# Altium schematic sheet Mix_8ch.SchDoc(Mix_8ch)
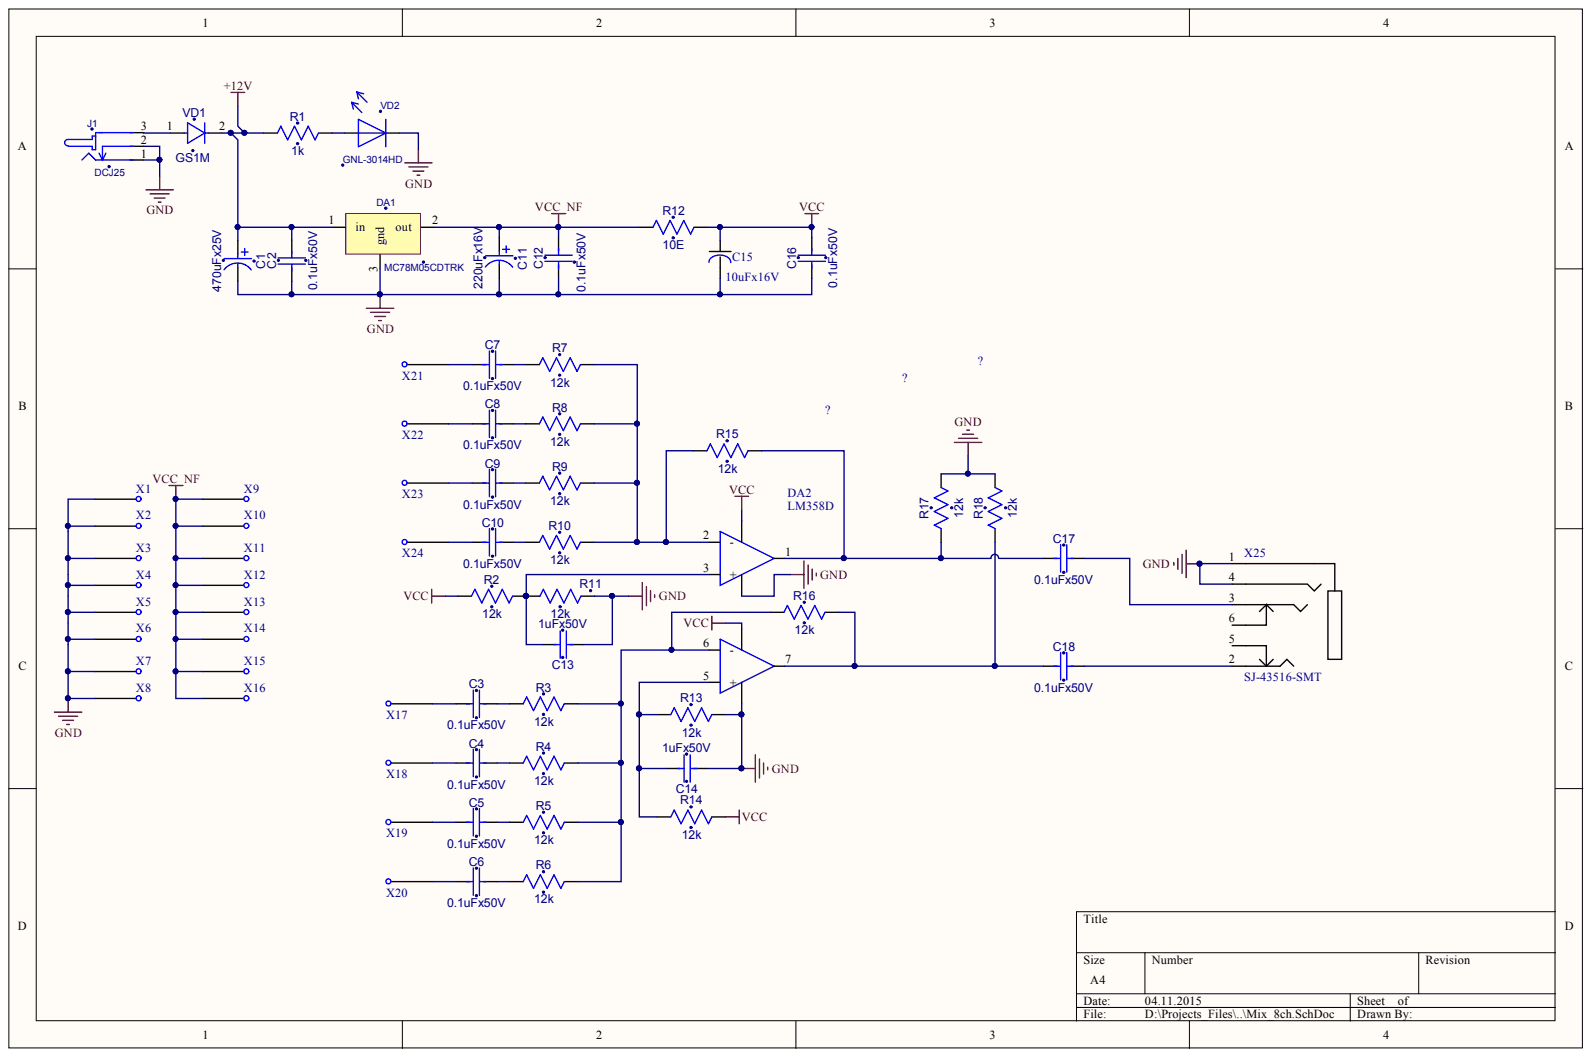
[diagram p0: Mix_8ch.SchDoc]

## Parts
?  REPER1.2  [REPER1.2]  (value not drawn)
?  REPER1.2  [REPER1.2]  (value not drawn)
?  REPER1.2  [REPER1.2]  (value not drawn)
C1  470uFx25V  [CC16X10_CAP0.5(1)(Primary)]
C2  0.1uFx50V  [CC0603-3M]
C3  0.1uFx50V  [CC0603-3M]
C4  0.1uFx50V  [CC0603-3M]
C5  0.1uFx50V  [CC0603-3M]
C6  0.1uFx50V  [CC0603-3M]
C7  0.1uFx50V  [CC0603-3M]
C8  0.1uFx50V  [CC0603-3M]
C9  0.1uFx50V  [CC0603-3M]
C10  0.1uFx50V  [CC0603-3M]
C11  220uFx16V  [CC8X3.5]
C12  0.1uFx50V  [CC0603-3M]
C13  1uFx50V  [CC0603-3M]
C14  1uFx50V  [CC0603-3M]
C15  10uFx16V  [Cap_5x8]
C16  0.1uFx50V  [CC0603-3M]
C17  0.1uFx50V  [CC0603-3M]
C18  0.1uFx50V  [CC0603-3M]
DA1  MC78M05CDTRK  [D-PAK(TO-252AA)]
DA2  LM358D  [SO-8]
J1  DCJ25  [DCJ25]
R1  1k  [RC0603-3M]
R2  12k  [RC0603-3M]
R3  12k  [RC0603-3M]
R4  12k  [RC0603-3M]
R5  12k  [RC0603-3M]
R6  12k  [RC0603-3M]
R7  12k  [RC0603-3M]
R8  12k  [RC0603-3M]
R9  12k  [RC0603-3M]
R10  12k  [RC0603-3M]
R11  12k  [RC0603-3M]
R12  10E  [RC0805_IPC_NOM]
R13  12k  [RC0603-3M]
R14  12k  [RC0603-3M]
R15  12k  [RC0603-3M]
R16  12k  [RC0603-3M]
R17  12k  [RC0603-3M]
R18  12k  [RC0603-3M]
VD1  GS1M  [SMA]
VD2  GNL-3014HD  [LED3]
X1  PIN_1mm  [PIN_1mm]  (value not drawn)
X2  PIN_1mm  [PIN_1mm]  (value not drawn)
X3  PIN_1mm  [PIN_1mm]  (value not drawn)
X4  PIN_1mm  [PIN_1mm]  (value not drawn)
X5  PIN_1mm  [PIN_1mm]  (value not drawn)
X6  PIN_1mm  [PIN_1mm]  (value not drawn)
X7  PIN_1mm  [PIN_1mm]  (value not drawn)
X8  PIN_1mm  [PIN_1mm]  (value not drawn)
X9  PIN_1mm  [PIN_1mm]  (value not drawn)
X10  PIN_1mm  [PIN_1mm]  (value not drawn)
X11  PIN_1mm  [PIN_1mm]  (value not drawn)
X12  PIN_1mm  [PIN_1mm]  (value not drawn)
X13  PIN_1mm  [PIN_1mm]  (value not drawn)
X14  PIN_1mm  [PIN_1mm]  (value not drawn)
X15  PIN_1mm  [PIN_1mm]  (value not drawn)
X16  PIN_1mm  [PIN_1mm]  (value not drawn)
X17  PIN_1mm  [PIN_1mm]  (value not drawn)
X18  PIN_1mm  [PIN_1mm]  (value not drawn)
X19  PIN_1mm  [PIN_1mm]  (value not drawn)
X20  PIN_1mm  [PIN_1mm]  (value not drawn)
X21  PIN_1mm  [PIN_1mm]  (value not drawn)
X22  PIN_1mm  [PIN_1mm]  (value not drawn)
X23  PIN_1mm  [PIN_1mm]  (value not drawn)
X24  PIN_1mm  [PIN_1mm]  (value not drawn)
X25  SJ-43516-SMT  [SJ-43516-SMT]

## Nets
+12V: C1.2 C2.2 DA1.1 R1.1 VD1.2
GND: C1.1 C2.1 C11.2 C12.1 C13.1 C14.1 C15.- C16.1 DA1.3 DA2.4 J1.1 J1.2 R11.2 R13.2 R17.2 R18.2 VD2.1 X1.1 X2.1 X3.1 X4.1 X5.1 X6.1 X7.1 X8.1 X25.1 X25.4
VCC: C15.+ C16.2 DA2.8 R2.1 R12.2 R14.2
VCC_NF: C11.1 C12.2 DA1.2 R12.1 X9.1 X10.1 X11.1 X12.1 X13.1 X14.1 X15.1 X16.1
NetC3_1: C3.1 X17.1
NetC3_2: C3.2 R3.1
NetC4_1: C4.1 X18.1
NetC4_2: C4.2 R4.1
NetC5_1: C5.1 X19.1
NetC5_2: C5.2 R5.1
NetC6_1: C6.1 X20.1
NetC6_2: C6.2 R6.1
NetC7_1: C7.1 X21.1
NetC7_2: C7.2 R7.1
NetC8_1: C8.1 X22.1
NetC8_2: C8.2 R8.1
NetC9_1: C9.1 X23.1
NetC9_2: C9.2 R9.1
NetC10_1: C10.1 X24.1
NetC10_2: C10.2 R10.1
NetC13_2: C13.2 DA2.3(+) R2.2 R11.1
NetC14_2: C14.2 DA2.5(+) R13.1 R14.1
NetC17_1: C17.1 DA2.1 R15.2 R17.1
NetC17_2: C17.2 X25.3
NetC18_1: C18.1 DA2.7 R16.2 R18.1
NetC18_2: C18.2 X25.2
NetDA2_2: DA2.2(-) R7.2 R8.2 R9.2 R10.2 R15.1
NetDA2_6: DA2.6(-) R3.2 R4.2 R5.2 R6.2 R16.1
NetJ1_3: J1.3 VD1.1
NetR1_2: R1.2 VD2.2

Not connected: X25 5 6

## Library data of the parts
C1 = CAP0.5(1): Jamicon TKR; Component Kind: Standard; Value: 470uFx25V; Library Name: gprs_modem.SchLib
C2-C10 C12-C14 C16-C18 = CC0603: CC0603 X7R; Component Kind: Standard; Library Name: gprs_modem.SchLib
  C2-C10 C12 C16-C18: Value: 0.1uFx50V
  C13 C14: Value: 1uFx50V
C11 = CAP0.35: Component Kind: Standard; Description: CAP0.35; Value: 220uFx16V; Library Name: gprs_modem.SchLib
C15 = Cap_5x8: Jamicon TKR; Component Kind: Standard; Value: 10uFx16V; Library Name: VHF.SchLib
R1-R11 R13-R18 = RC0603: Component Kind: Standard; Description: RC0603
  R1: Value: 1k; Library Name: Schetchik.SchLib
  R2-R11 R13-R18: Value: 12k; Library Name: gprs_modem.SchLib
R12 = RC0805: Component Kind: Standard; Description: RC0805; Value: 10E; Library Name: gprs_modem.SchLib
VD1 = GS1M: Value: {Value}; Component Kind: Standard; Description: GS1M; Library Name: Schetchik.SchLib
VD2 = LED_3: Component Kind: Standard; Description: LED_3; Value: GNL-3014HD; Library Name: Schetchik.SchLib
X25 = SJ-43516-SMT: 3.5mm connector 4 conductors; Component Kind: Standard; Library Name: gprs_modem.SchLib
? ? ? = REPER1.2: Component Kind: Standard; Description: REPER1.2; Library Name: gprs_modem.SchLib
DA1 = MC78M05CDTRK: Component Kind: Standard; Description: MC78M05CDTRK; Library Name: gprs_modem.SchLib
DA2 = LM358D: Component Kind: Standard; Value: LM358D; Library Name: VHF.SchLib
J1 = DCJ25: Component Kind: Standard; Description: DCJ25; Library Name: Schetchik.SchLib
X1-X24 = PIN_1mm: Component Kind: Standard; Library Name: gprs_modem.SchLib
